# Altium schematic sheet IHM.SchDoc(IHM)
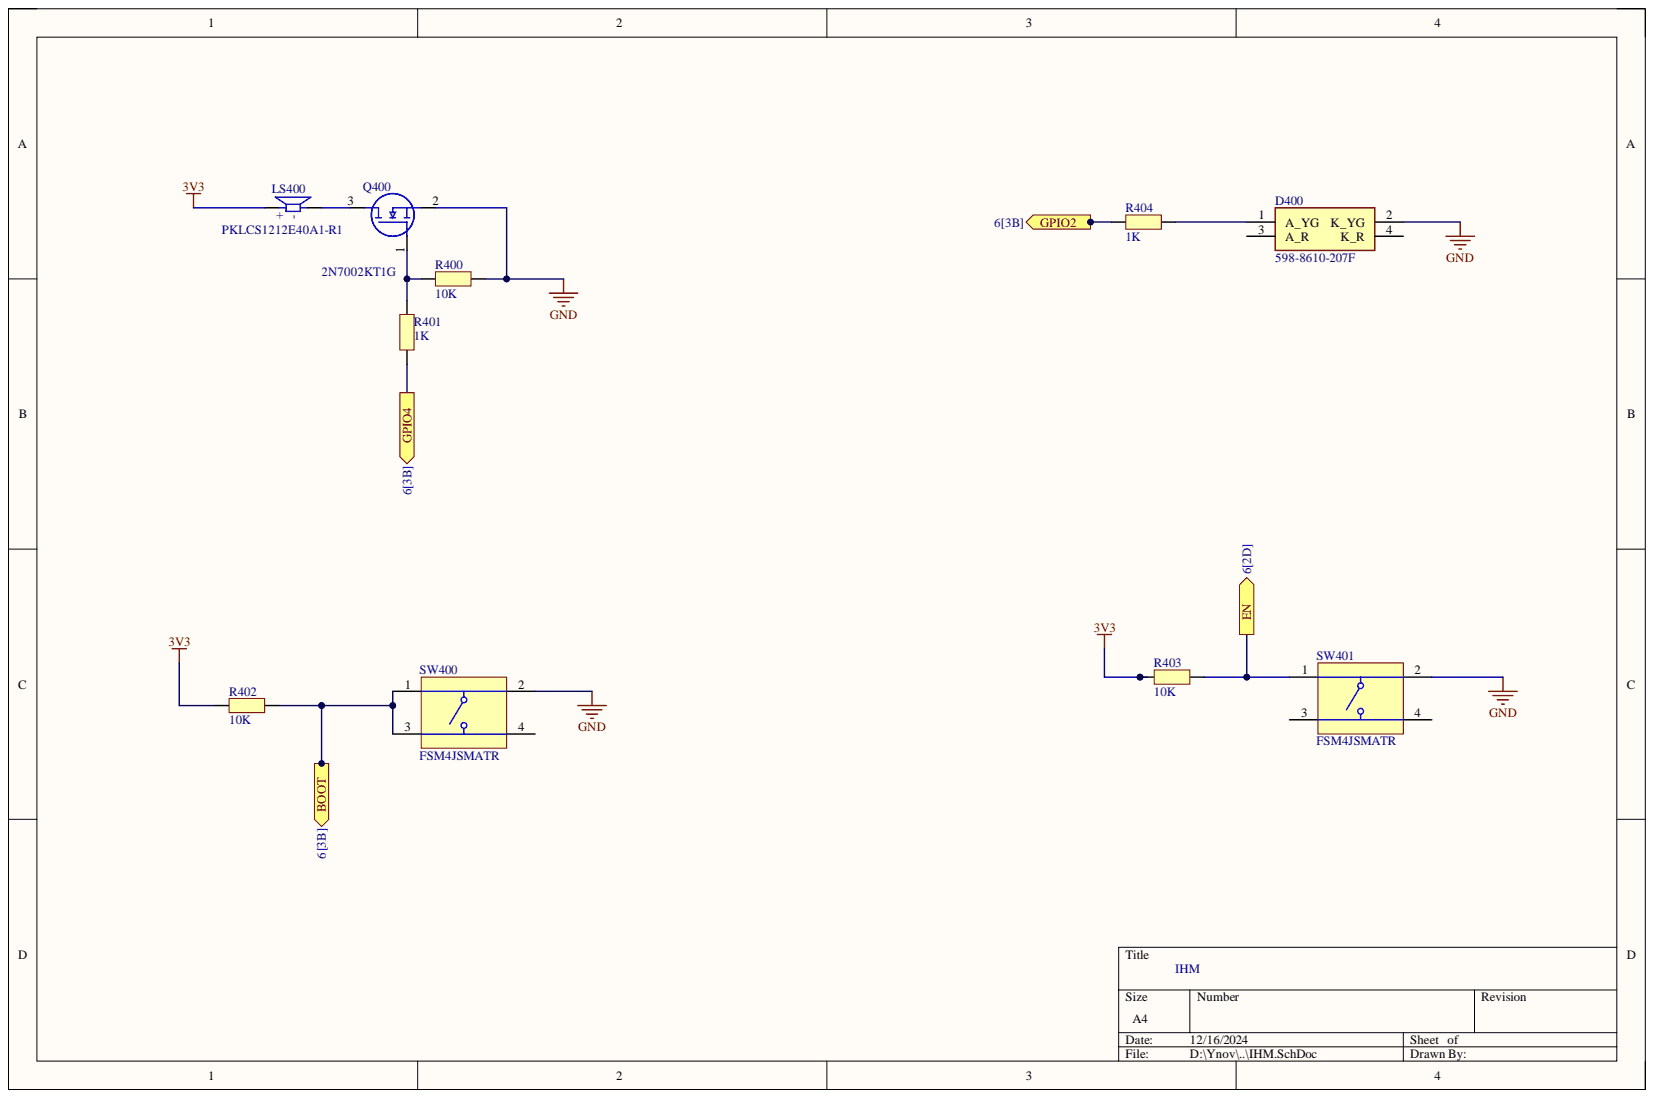
[diagram p0: IHM.SchDoc]

## Parts
D400  598-8610-207F  [5988610207F]
LS400  PKLCS1212E40A1-R1  [SPKR_PKLCS1212E40A1-R1]
Q400  2N7002KT1G  [SOT96P237X111-3N]
R400  10K  [RESC1005X06N]
R401  1K  [RESC1005X06N]
R402  10K  [RESC1005X06N]
R403  10K  [RESC1005X06N]
R404  1K  [RESC1005X06N]
SW400  FSM4JSMATR  [TECO-FSM4JSMATR_V]
SW401  FSM4JSMATR  [TECO-FSM4JSMATR_V]

## Ports
BOOT, EN, GPIO2, GPIO4

## Nets
3V3: LS400.P R402.2 R403.2
GND: D400.2(K_YG) Q400.2 R400.2 SW400.2 SW401.2
BOOT: R402.1 SW400.1 SW400.3 ; port BOOT
EN: R403.1 SW401.1 ; port EN
GPIO2: R404.2 ; port GPIO2
GPIO4: R401.1 ; port GPIO4
NetD400_1: D400.1(A_YG) R404.1
NetLS400_N: LS400.N Q400.3
NetQ400_1: Q400.1 R400.1 R401.2

Not connected: D400 3(A_R) 4(K_R); SW400 4; SW401 3 4

## Library data of the parts
D400 = 598-8610-207F: Standard LEDs - SMD Red/Green WC 80/50mcd 645/570nm; Manufacturer_Name: Dialight; Geometry.Height: 0.8mm; Arrow Part Number: 598-8610-207F; Arrow Price/Stock: https://www.arrow.com/en/products/598-8610-207f/dialight?region=nac; Component Kind: Standard; Datasheet Link: https://media.digikey.com/pdf/Data%20Sheets/Dialight%20PDFs/598%20Series%201210%20Pkg%20Bi-Color.pdf; Library Name: SCHLibrary.SchLib; Manufacturer_Part_Number: 598-8610-207F; Mouser Part Number: 645-598-8610-207F; Mouser Price/Stock: https://www.mouser.co.uk/ProductDetail/Dialight/598-8610-207F?qs=7JStj%2FjQ2SFuCjosqwklHQ%3D%3D
LS400 = PKLCS1212E40A1-R1: Buzzers Transducer, Externally Driven Piezo 1.5V 2kHz 70dB @ 1.5V, 10cm Surface Mount Solder Pads; MF: Murata Electronics; Availability: Unavailable; Component Kind: Standard; Library Name: SCHLibrary.SchLib; MP: PKLCS1212E40A1-R1; Package: None; Price: None
Q400 = 2N7002KT1G: MOSFET N-Ch 60V 380mA Small Signal SOT23 ON Semiconductor 2N7002KT1G N-channel MOSFET Transistor, 380 mA, 60 V, 3-Pin SOT-23; Manufacturer_Name: onsemi; Geometry.Height: 1.11mm; Arrow Part Number: 2N7002KT1G; Arrow Price/Stock: https://www.arrow.com/en/products/2n7002kt1g/on-semiconductor?utm_currency=USD&region=nac; Component Kind: Standard; Datasheet Link: http://www.onsemi.com/pub/Collateral/2N7002K-D.PDF; Library Name: SCHLibrary.SchLib; Manufacturer_Part_Number: 2N7002KT1G; Mouser Part Number: 863-2N7002KT1G; Mouser Price/Stock: https://www.mouser.co.uk/ProductDetail/onsemi/2N7002KT1G?qs=Jh7l0IbPjifUiTytu%252BGGDQ%3D%3D
R400-R404 = Resistor: Component Kind: Standard; Library Name: SCHLibrary.SchLib
SW400 SW401 = CMP-2000-07486-1: Micro Miniature Push Button Switch FSM JSM, 50 mA, -35 to 85 degC, 4-Pin SMD, RoHS, Tape and Reel; Manufacturer: TE Connectivity; Actuator Length: 0.055inch; Actuator Material: Polyamide; Capacitance: 0f; Case\/Package: SMD/SMT; Contact Current Rating: 50mA; Contact Resistance: 100mOhm; Electrical Life: 100000Cycles; Illumination Type: Illuminated; Max Voltage Rating (DC): 12V; Operating Force: 160gf; Switch Travel: 0.25mm; Throw Configuration: SPST; Voltage Rating (DC): 24V; Component Kind: Standard; Contact Material: CopperAlloy; Contact Plating: Silver; Current Rating: 50mA; Datasheet URL: http://www.te.com/commerce/DocumentDelivery/DDEController?Action=showdoc&DocId=Customer+Drawing%7F2-1437565-7%7FV%7Fpdf%7FEnglish%7FENG_CD_2-1437565-7_V.pdf%7F4-1437565-2; Datasheet Version: Rev. V, 02/2015; Library Name: SCHLibrary.SchLib; Manufacturer URL: http://www.te.com/; Max Operating Temperature: 85degC; Mechanical Life: 100000Cycles; Min Operating Temperature: -35degC; Mount: SurfaceMount; Mounting Technology: SurfaceMount; Orientation: Straight; Package Description: 4-Pin Surface Mount Device, Body 6 x 6 mm, Pitch 4.5 mm; Package Quantity: 1200; Package Reference: FSM4JSMATR; Packaging: TapeandReel; REACH SVHC: Unknown; RoHSCompliant: true; Termination: GullWing
